# Altium schematic sheet 04_Screen.SchDoc
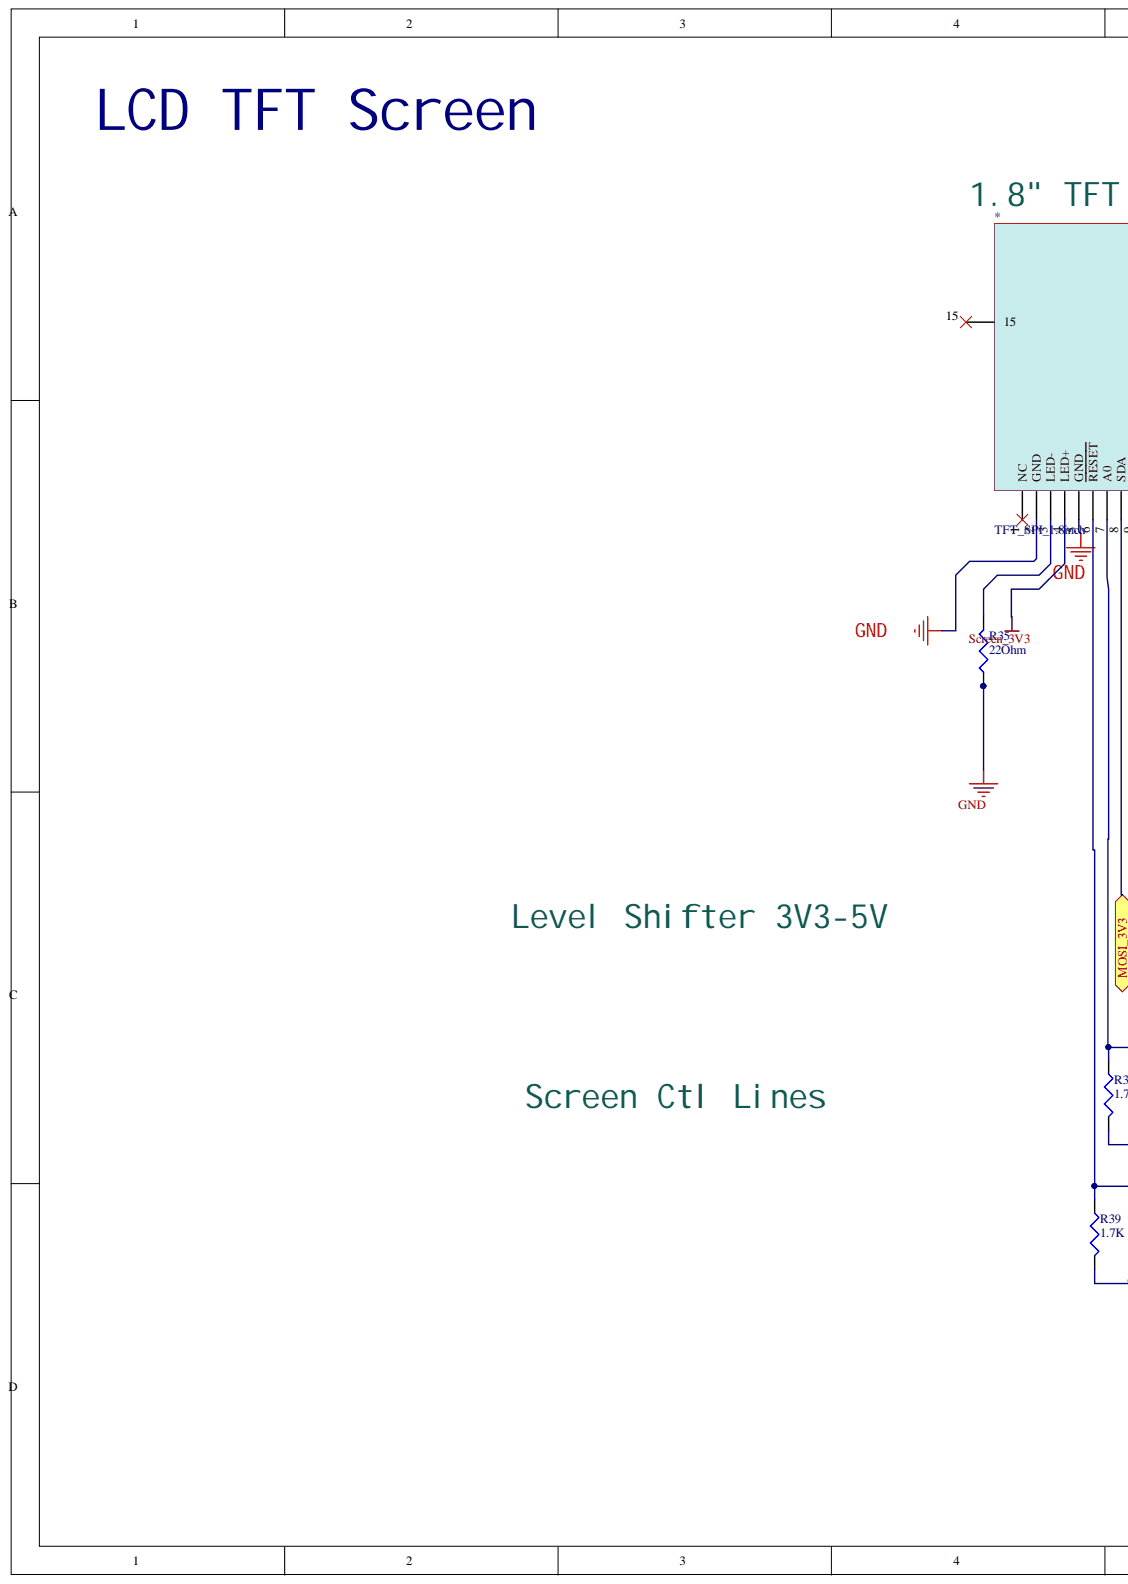
[diagram p0: 04_Screen.SchDoc, left]
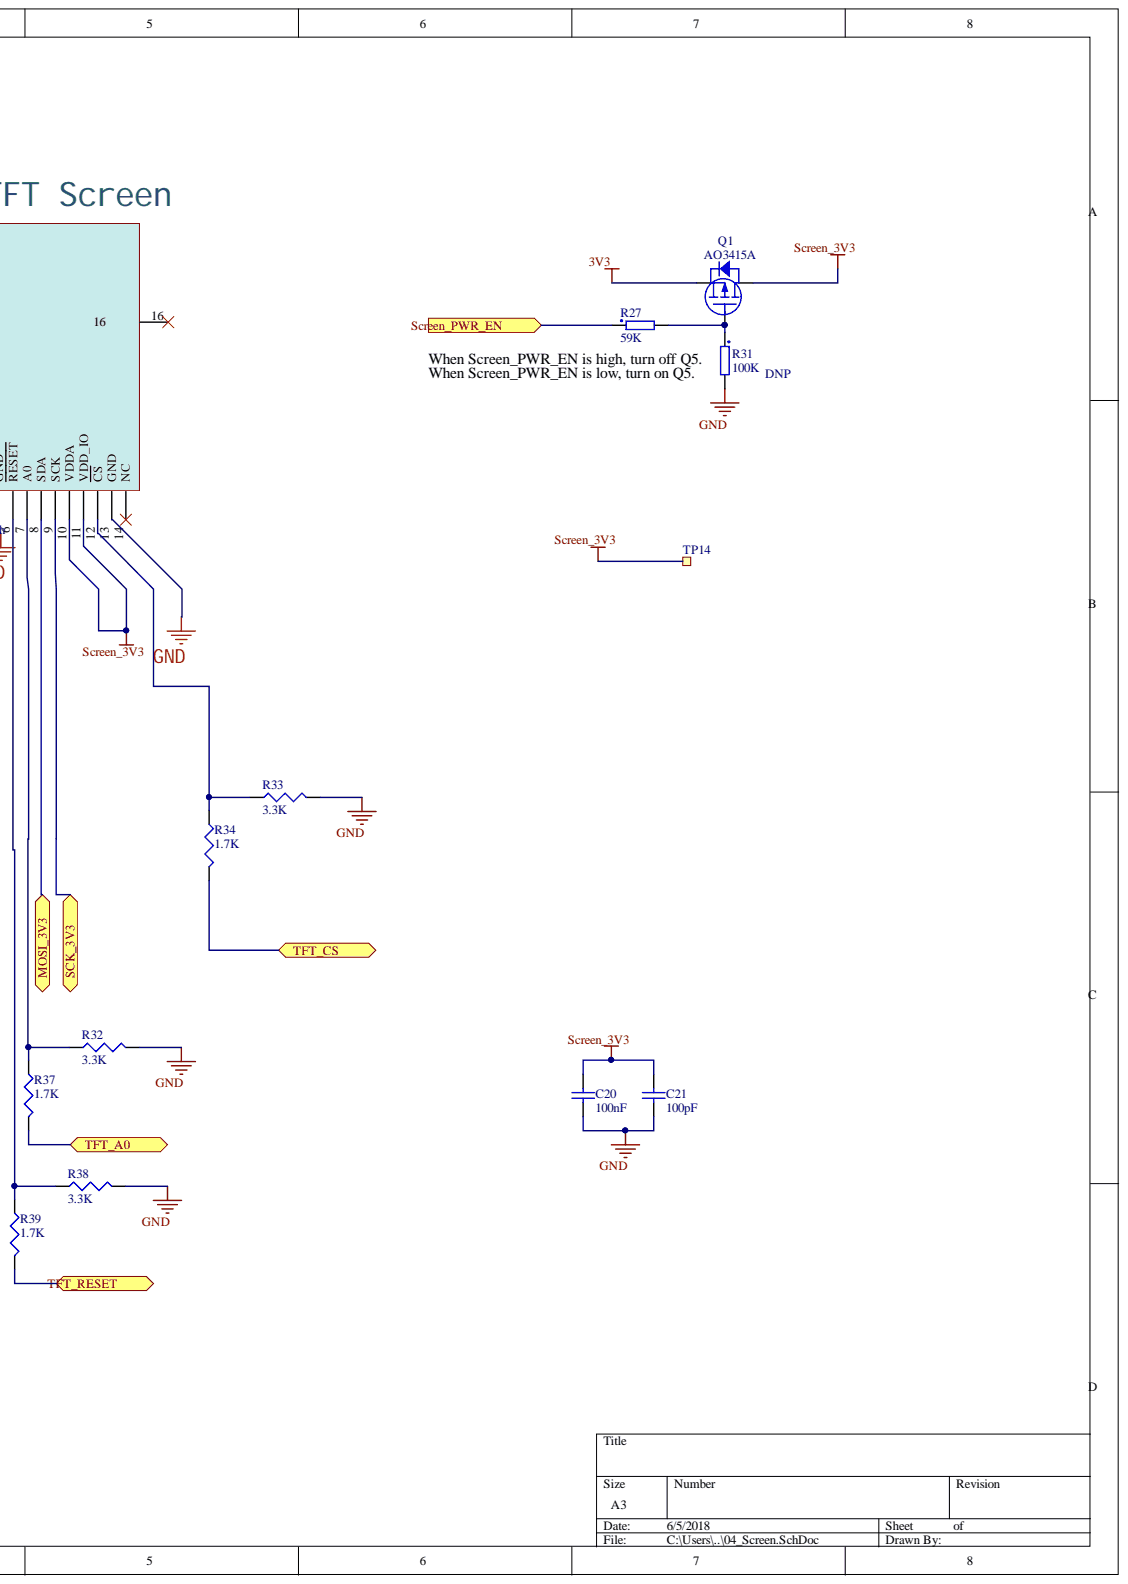
[diagram p1: 04_Screen.SchDoc, right]

## Parts
*  TFT_SPI_1.8inch  [TFT_1.8inch_PCB_PAD]
C20  100nF  [CAP_0603_SMD]
C21  100pF  [CAP_0603_SMD]
Q1  AO3415A  [SOT23-D]
R27  59K  [RES_0603_smd]
R31  100K  [RES_0603_smd]
R32  3.3K  [RES_0603_SMD]
R33  3.3K  [RES_0603_SMD]
R34  1.7K  [RES_0603_SMD]
R35  22Ohm  [RES_0603_SMD]
R37  1.7K  [RES_0603_SMD]
R38  3.3K  [RES_0603_SMD]
R39  1.7K  [RES_0603_SMD]
TP14  TP  [TP_1mm]  (no BOM)

## Ports
MOSI_3V3, SCK_3V3, SCREEN_PWR_EN, TFT_A0, TFT_CS, TFT_RESET

## Nets
3V3: Q1.3
GND: *.2(GND) *.5(GND) *.13(GND) C20.2 C21.2 R31.2 R32.2 R33.2 R35.1 R38.2
SCREEN_3V3: *.4(LED+) *.10(VDDA) *.11(VDD_IO) C20.1 C21.1 Q1.2 TP14.1
MOSI_3V3: *.8(SDA) ; port MOSI_3V3
SCK_3V3: *.9(SCK) ; port SCK_3V3
TFT_A0: R37.2 ; port TFT_A0
TFT_CS: R34.2 ; port TFT_CS
TFT_RESET: R39.2 ; port TFT_RESET
Net*_3: *.3(LED-) R35.2
Net*_6: *.6(RESET) R38.1 R39.1
Net*_7: *.7(A0) R32.1 R37.1
Net*_12: *.12(CS) R33.1 R34.1
NetQ1_1: Q1.1 R27.2 R31.1
NetR27_1: R27.1 ; port SCREEN_PWR_EN

Not connected: * 1(NC) 14(NC) 15 16

## Library data of the parts
C20 C21 = Cap Semi: Capacitor (Semiconductor SIM Model); Component Kind: Standard; LatestRevisionDate: 29-May-2009; LatestRevisionNote: IPC-7351 Footprint Added.; PackageDescription: Chip Capacitor; PackageReference: SMD 0805; Published: 8-Jun-2000; Signal Integrity: Cap Semi; Simulation: CAP; Library Name: C:\Users\Public\Documents\Altium\AD16\Library\Miscellaneous Devices.IntLib; Publisher: Altium Limited
  C20: Value: 100nF
  C21: Value: 100pF
Q1 = MOS-P-D: Component Kind: Standard; Library Name: M46_SCHLIB.SchLib
R27 R31 = RES: Resistor; Component Kind: Standard; Library Name: M46_SCHLIB.SchLib
R32-R35 R37-R39 = Res3: Resistor; Component Kind: Standard; LatestRevisionDate: 29-May-2009; LatestRevisionNote: IPC-7351 Footprint Added.; PackageDescription: Chip Resistor; Published: 8-Jun-2000; Signal Integrity: Res; Simulation: RESISTOR; Publisher: Altium Limited
  R32 R33 R38: PackageReference: SMD 0805; Value: 3.3K
  R34 R37 R39: PackageReference: SMD 0805; Value: 1.7K
  R35: Library Name: Miscellaneous Devices.IntLib; PackageReference: J1-0603; Value: 22Ohm
  R32-R34 R37-R39: Library Name: C:\Users\Public\Documents\Altium\AD16\Library\Miscellaneous Devices.IntLib
TP14 = Testpoint TH: Testpoint, through hole; Artikkelnr Noca: N.A.; Artikkelnr Simpro: N.A.; Footprint Doc: TESTPAD-TH; LatestRevisionDate: 2009-12-12; Library Name: pca10056.IntLib; Part Number: Testpoint TH; Pin Count: 1; Published: 2009-12-12; Value: TP; Publisher: Nordic Semiconductor
* = TFT_SPI_1.8inch: Component Kind: Standard; Library Name: ATmega2560_Library.SchLib
